# Altium schematic sheet Sheet3.SchDoc(Sheet3)
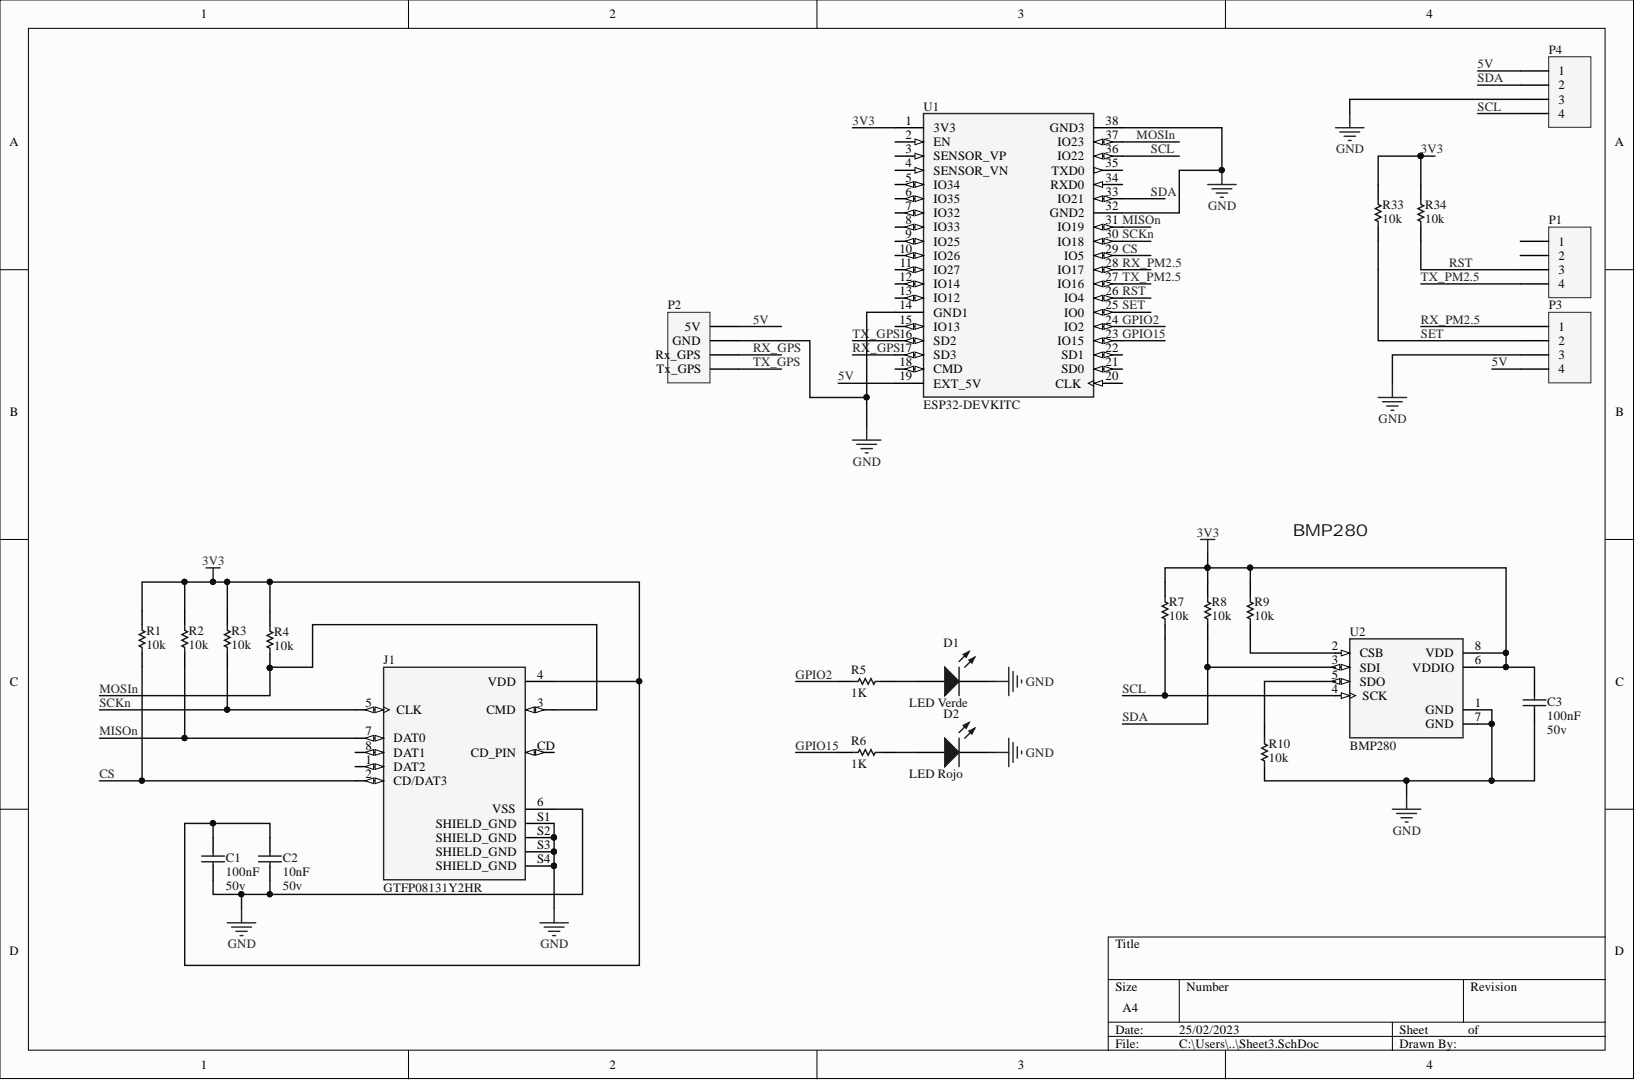
[diagram p0: Sheet3.SchDoc]

## Parts
C1  100nF  Volte: 50v  [MY_0603]
C2  10nF  Volte: 50v  [MY_0603]
C3  100nF  Volte: 50v  [MY_0603]
D1  LED  [MY_LED_0805]
D2  LED  [MY_LED_0805]
J1  GTFP08131Y2HR  [AMPHENOL_GTFP08131Y2HR]
P1  Header 4  [MY_6410-04]  (value not drawn)
P2  Header 4  [MY_6410-04]  (value not drawn)
P3  Header 4  [MY_6410-04]  (value not drawn)
P4  Header 4  [MY_6410-04]  (value not drawn)
R1  10k  [MY_0603]
R2  10k  [MY_0603]
R3  10k  [MY_0603]
R4  10k  [MY_0603]
R5  1K  [MY_0603]
R6  1K  [MY_0603]
R7  10k  [MY_0603]
R8  10k  [MY_0603]
R9  10k  [MY_0603]
R10  10k  [MY_0603]
R33  10k  [MY_0603]
R34  10k  [MY_0603]
U1  ESP32-DEVKITC  [MODULE_ESP32-DEVKITC]
U2  BMP280  [XDCR_BMP280]

## Nets
GND: C1.1 C2.1 C3.1 D1.K D2.K J1.6(VSS) J1.S1(SHIELD_GND) J1.S2(SHIELD_GND) J1.S3(SHIELD_GND) J1.S4(SHIELD_GND) P2.3(GND) P3.3 P4.3 R10.1 U1.14(GND1) U1.32(GND2) U1.38(GND3) U2.1(GND) U2.7(GND)
3V3: C1.2 C2.2 C3.2 J1.4(VDD) R1.2 R2.2 R3.2 R4.2 R7.2 R8.2 R9.2 R33.2 R34.2 U1.1(3V3) U2.6(VDDIO) U2.8(VDD)
5V: P2.4(5V) P3.4 P4.1 U1.19(EXT_5V)
CS: J1.2(CD/DAT3) R1.1 U1.29(IO5)
GPIO2: R5.1 U1.24(IO2)
GPIO15: R6.2 U1.23(IO15)
MISOn: J1.7(DAT0) R2.1 U1.31(IO19)
MOSIn: J1.3(CMD) R4.1 U1.37(IO23)
RST: P1.3 R34.1 U1.26(IO4)
RX_GPS: P2.2 U1.17(SD3)
RX_PM2.5: P3.1 U1.28(IO17)
SCKn: J1.5(CLK) R3.1 U1.30(IO18)
SCL: P4.4 R7.1 U1.36(IO22) U2.4(SCK)
SDA: P4.2 R8.1 U1.33(IO21) U2.3(SDI)
SET: P3.2 R33.1 U1.25(IO0)
TX_GPS: P2.1 U1.16(SD2)
TX_PM2.5: P1.4 U1.27(IO16)
NetD1_A: D1.A R5.2
NetD2_A: D2.A R6.1
NetR9_1: R9.1 U2.2(CSB)
NetR10_2: R10.2 U2.5(SDO)

Not connected: J1 1(DAT2) 8(DAT1) CD(CD_PIN); P1 1 2; U1 2(EN) 3(SENSOR_VP) 4(SENSOR_VN) 5(IO34) 6(IO35) 7(IO32) 8(IO33) 9(IO25) 10(IO26) 11(IO27) 12(IO14) 13(IO12) 15(IO13) 18(CMD) 20(CLK) 21(SD0) 22(SD1) 34(RXD0) 35(TXD0)

## Library data of the parts
C1-C3 = Cap ceramic: None polar capacitor; ASiD Part Number: C-AS; Library Name: Mylib+Rev+4.IntLib; Component Kind: Standard
  C1 C3: Value: 100nF
  C2: Value: 10nF
D1 D2 = LED: Typical INFRARED GaAs LED; ASiD Part Number: LED-AS; Library Name: Mylib+Rev+4.IntLib; Component Kind: Standard
P1-P4 = Header 4: Header, 4-Pin; ASiD Part Number: Header 4-AS; Signal Integrity: Connector; Library Name: Mylib+Rev+4.IntLib; Component Kind: Standard
R1-R10 R33 R34 = Res: Standard resistor; ASiD Part Number: Res-AS; Library Name: Mylib+Rev+4.IntLib; Component Kind: Standard
  R1-R4 R7-R10 R33 R34: Value: 10k
  R5 R6: Value: 1K
U2 = BMP280: BMP280 Absolute Barometric Pressure Sensor for Battery Powered Devices 2 x 2.5mm; Price: None; Package: None; MP: BMP280; Library Name: BMP280.IntLib; Component Kind: Standard; Availability: Unavailable
J1 = GTFP08131Y2HR: Price: None; Package: None; MP: GTFP08131Y2HR; Library Name: GTFP08131Y2HR.IntLib; Component Kind: Standard; Availability: Unavailable
U1 = ESP32-DEVKITC: Price: None; Package: None; MP: ESP32-DEVKITC; Library Name: ESP32-DEVKITC.IntLib; Description: ESP32-DEVKITC; Component Kind: Standard; Availability: Unavailable
